# Altium schematic sheet QUTMS-TSAL-S00.SchDoc(QUTMS-TSAL-S00)
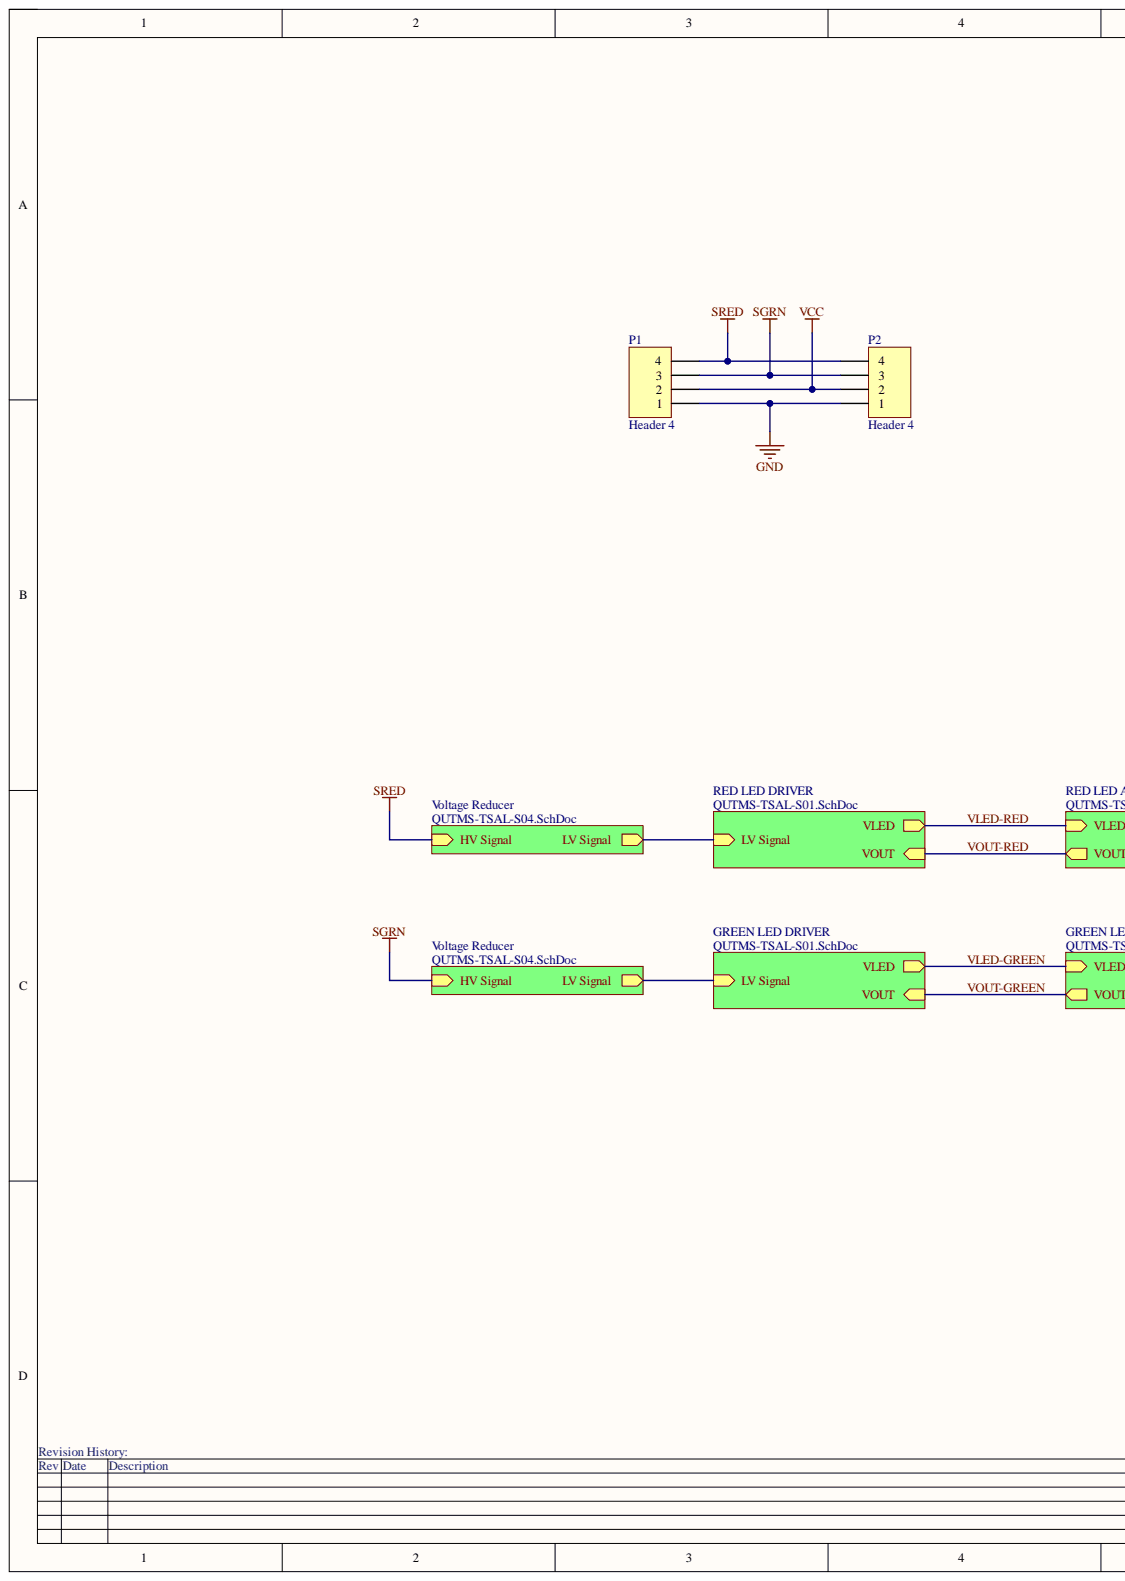
[diagram p0: QUTMS-TSAL-S00.SchDoc, left]
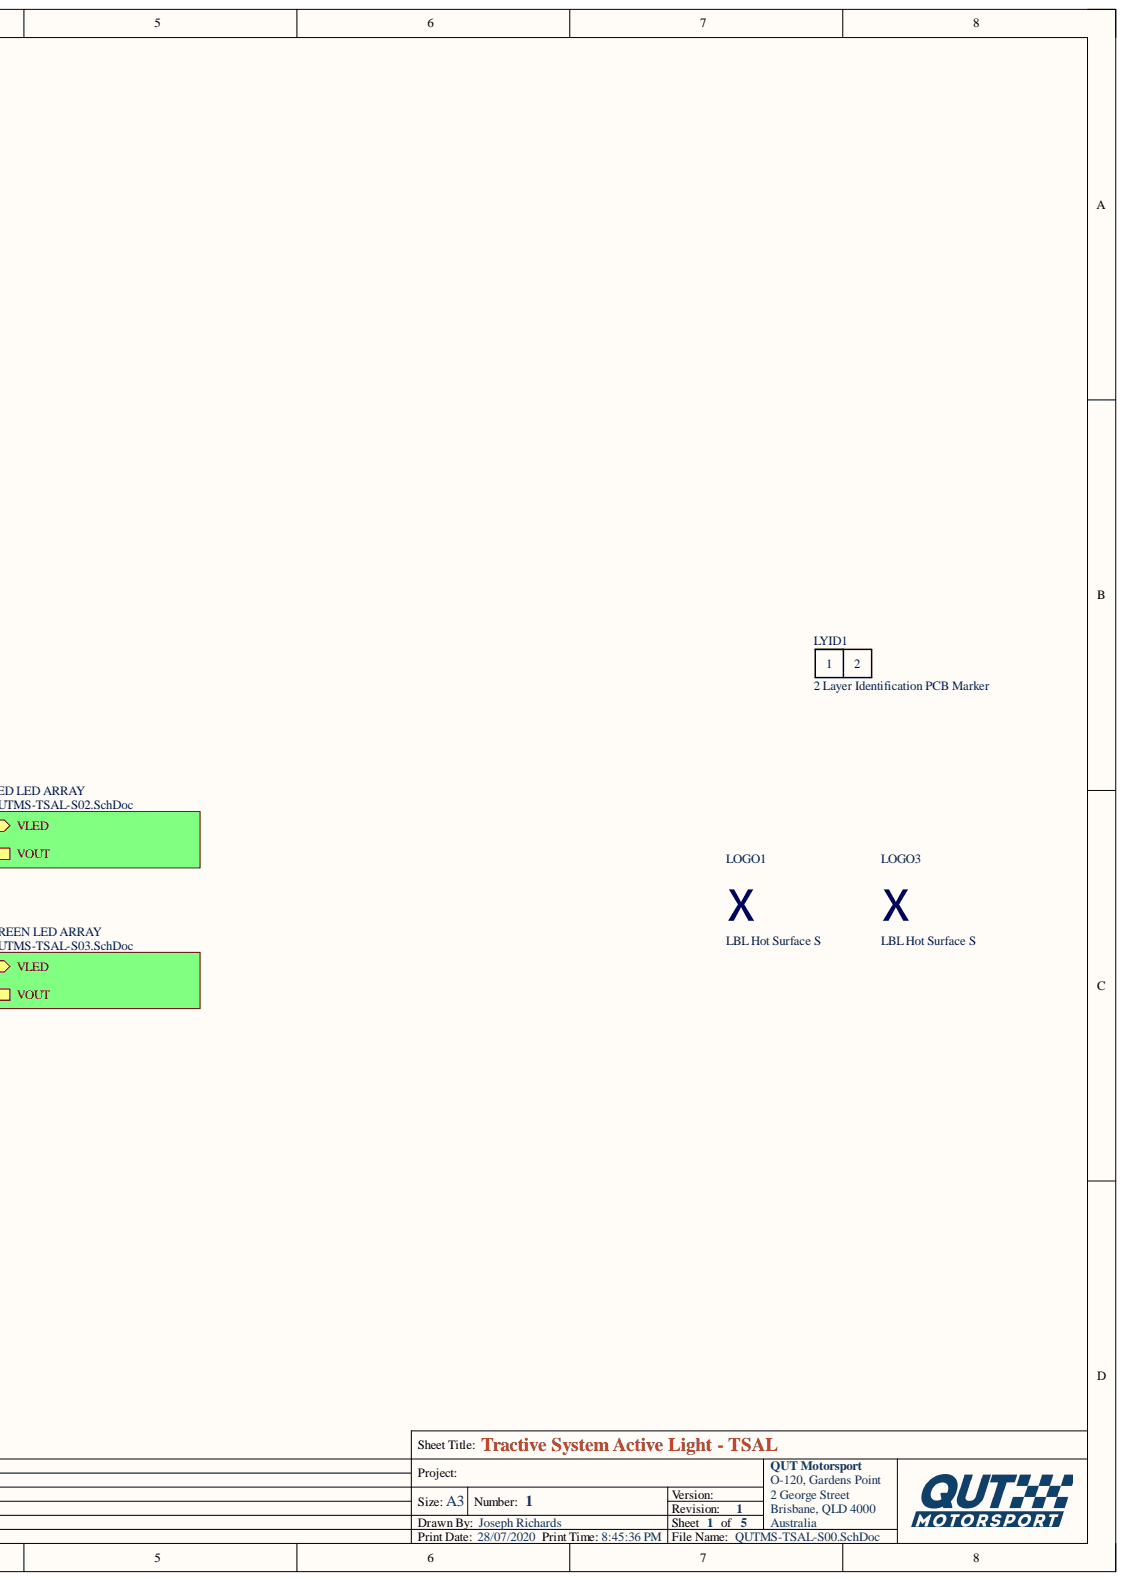
[diagram p1: QUTMS-TSAL-S00.SchDoc, right]

## Parts
LOGO1  LBL Hot Surface S  [LBL HOT SMALL]
LOGO3  LBL Hot Surface S  [LBL HOT SMALL]
LYID1  2 Layer Identification PCB Marker  [LAYER IDENT 2]
P1  Header 4  [HDR1X4]
P2  Header 4  [HDR1X4]

## Nets
GND: P1.1 P2.1
SGRN: P1.3 P2.3
SRED: P1.4 P2.4
VCC: P1.2 P2.2
LV Signal:
VLED-GREEN:
VLED-RED:
VOUT-GREEN:
VOUT-RED:

## Library data of the parts
LYID1 = Layer Identification 2: Component Kind: Standard; Description: 2 Layer Identification PCB Marker; Library Name: QUTMS_PCBLibrary.SchLib
P1 P2 = Header 4: Header, 4-Pin; Component Kind: Standard; LatestRevisionDate: 17-Jul-2002; LatestRevisionNote: Re-released for DXP Platform.; Library Name: Miscellaneous Connectors.IntLib; Signal Integrity: Connector; Publisher: Altium Limited
LOGO1 LOGO3 = LBL Hot Surface S: Component Kind: Standard; Description: LBL Hot Surface S; Library Name: QUTMS_PCBLibrary.SchLib
